# Altium schematic sheet 5.04.51805A02_ STME2.SchDoc("5.04.51805A02_ STME2")
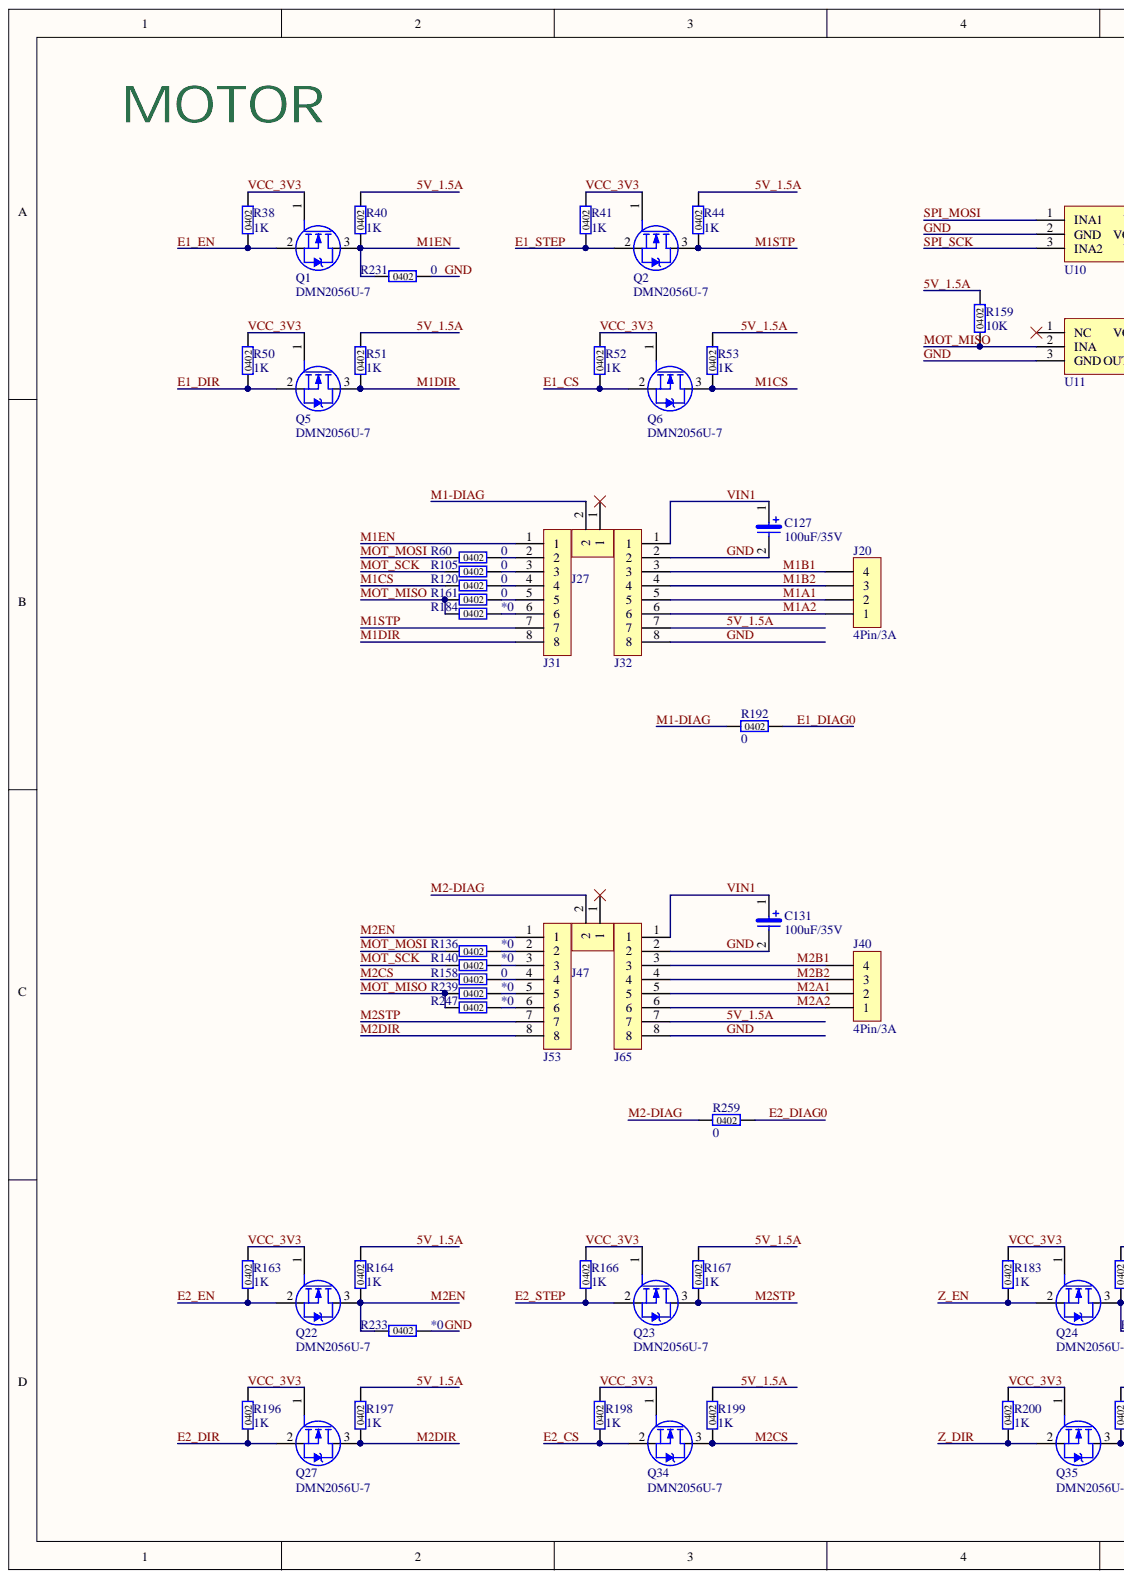
[diagram p0: 5.04.51805A02_ STME2.SchDoc, left]
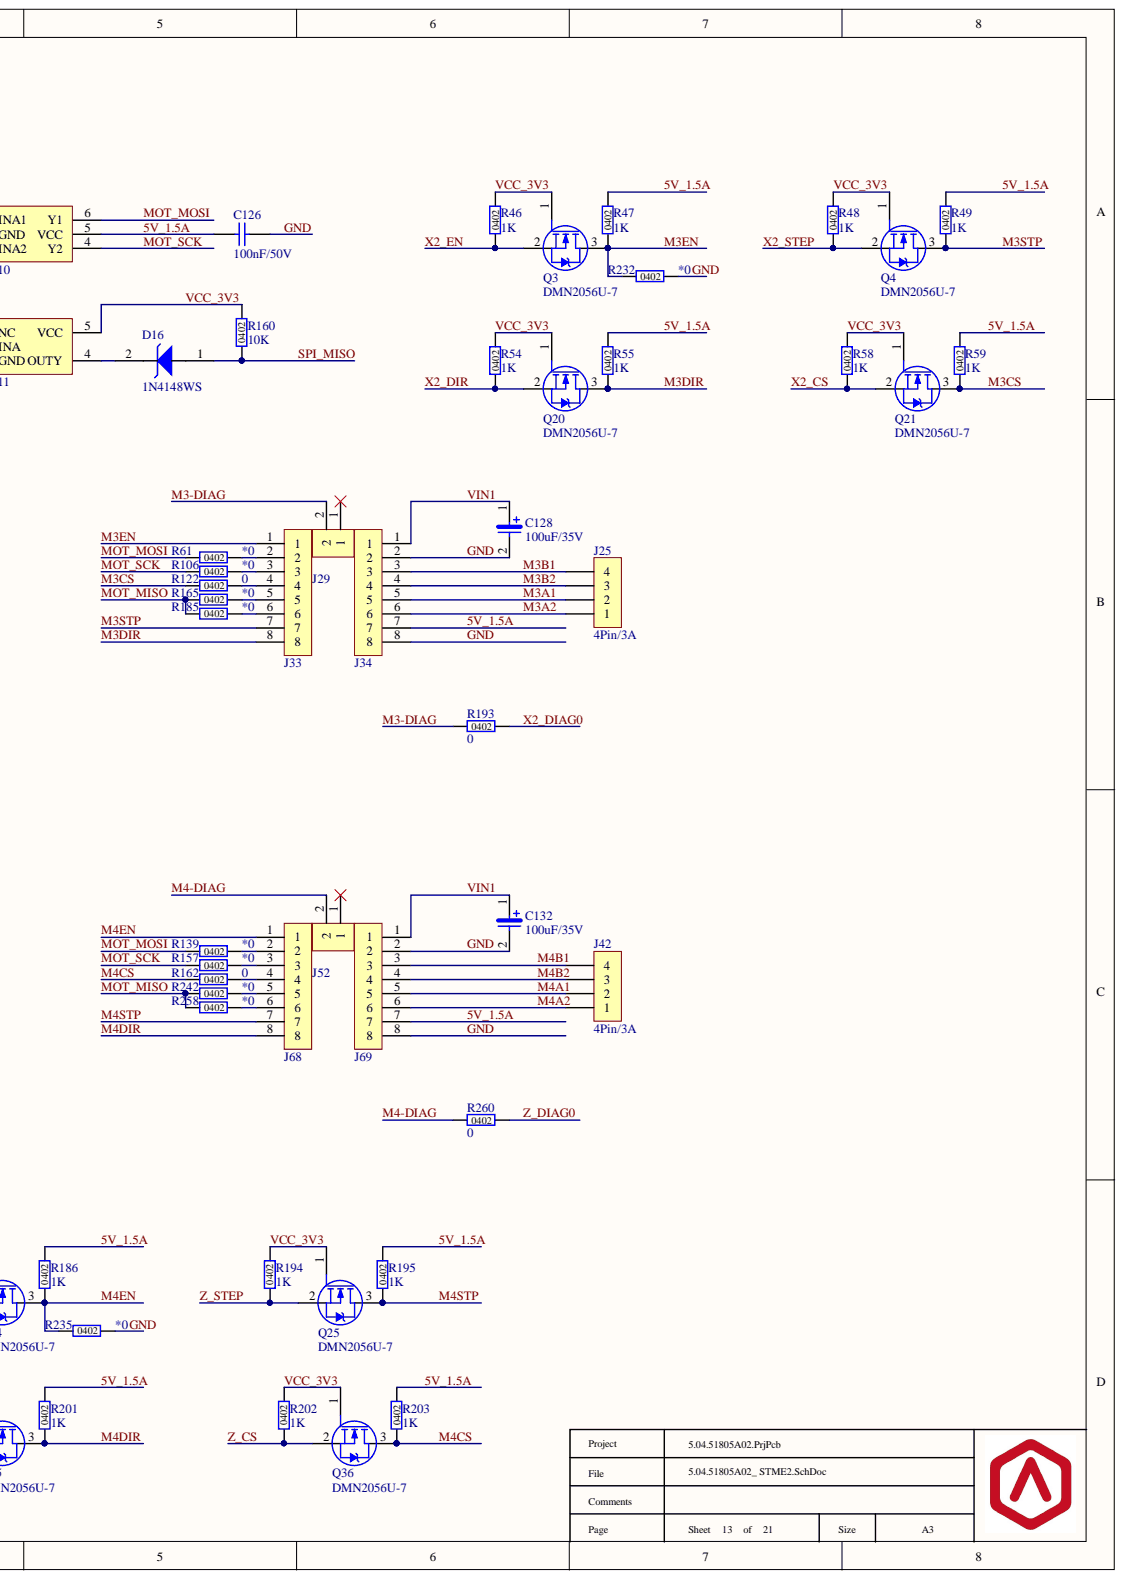
[diagram p1: 5.04.51805A02_ STME2.SchDoc, right]

## Parts
C126  100nF/50V  [C0402]
C127  100uF/35V  [ALC_260_260_307]
C128  100uF/35V  [ALC_260_260_307]
C131  100uF/35V  [ALC_260_260_307]
C132  100uF/35V  [ALC_260_260_307]
D16  1N4148WS  [SOD-323_L1.7-W1.3-LS2.5-FD]
J20  4Pin/3A  [B4B-XH-A(LF)(SN)]
J25  4Pin/3A  [B4B-XH-A(LF)(SN)]
J27  PM254-1-02-Z-8.5  [HDR-TH_2P-P2.54-V-F]  (value not drawn)
J29  PM254-1-02-Z-8.5  [HDR-TH_2P-P2.54-V-F]  (value not drawn)
J31  PM254-1-08-Z-8.5  [HDR-TH_8P-P2.54-V-F]  (value not drawn)
J32  PM254-1-08-Z-8.5  [HDR-TH_8P-P2.54-V-F]  (value not drawn)
J33  PM254-1-08-Z-8.5  [HDR-TH_8P-P2.54-V-F]  (value not drawn)
J34  PM254-1-08-Z-8.5  [HDR-TH_8P-P2.54-V-F]  (value not drawn)
J40  4Pin/3A  [B4B-XH-A(LF)(SN)]
J42  4Pin/3A  [B4B-XH-A(LF)(SN)]
J47  PM254-1-02-Z-8.5  [HDR-TH_2P-P2.54-V-F]  (value not drawn)
J52  PM254-1-02-Z-8.5  [HDR-TH_2P-P2.54-V-F]  (value not drawn)
J53  PM254-1-08-Z-8.5  [HDR-TH_8P-P2.54-V-F]  (value not drawn)
J65  PM254-1-08-Z-8.5  [HDR-TH_8P-P2.54-V-F]  (value not drawn)
J68  PM254-1-08-Z-8.5  [HDR-TH_8P-P2.54-V-F]  (value not drawn)
J69  PM254-1-08-Z-8.5  [HDR-TH_8P-P2.54-V-F]  (value not drawn)
Q1  DMN2056U-7  [SOT-23-3]
Q2  DMN2056U-7  [SOT-23-3]
Q3  DMN2056U-7  [SOT-23-3]
Q4  DMN2056U-7  [SOT-23-3]
Q5  DMN2056U-7  [SOT-23-3]
Q6  DMN2056U-7  [SOT-23-3]
Q20  DMN2056U-7  [SOT-23-3]
Q21  DMN2056U-7  [SOT-23-3]
Q22  DMN2056U-7  [SOT-23-3]
Q23  DMN2056U-7  [SOT-23-3]
Q24  DMN2056U-7  [SOT-23-3]
Q25  DMN2056U-7  [SOT-23-3]
Q27  DMN2056U-7  [SOT-23-3]
Q34  DMN2056U-7  [SOT-23-3]
Q35  DMN2056U-7  [SOT-23-3]
Q36  DMN2056U-7  [SOT-23-3]
R38  1K  [R0402]
R40  1K  [R0402]
R41  1K  [R0402]
R44  1K  [R0402]
R46  1K  [R0402]
R47  1K  [R0402]
R48  1K  [R0402]
R49  1K  [R0402]
R50  1K  [R0402]
R51  1K  [R0402]
R52  1K  [R0402]
R53  1K  [R0402]
R54  1K  [R0402]
R55  1K  [R0402]
R58  1K  [R0402]
R59  1K  [R0402]
R60  0  [R0402]
R61  *0  [R0402]
R105  0  [R0402]
R106  *0  [R0402]
R120  0  [R0402]
R122  0  [R0402]
R136  *0  [R0402]
R139  *0  [R0402]
R140  *0  [R0402]
R157  *0  [R0402]
R158  0  [R0402]
R159  10K  [R0402]
R160  10K  [R0402]
R161  0  [R0402]
R162  0  [R0402]
R163  1K  [R0402]
R164  1K  [R0402]
R165  *0  [R0402]
R166  1K  [R0402]
R167  1K  [R0402]
R183  1K  [R0402]
R184  *0  [R0402]
R185  *0  [R0402]
R186  1K  [R0402]
R192  0  [R0402]
R193  0  [R0402]
R194  1K  [R0402]
R195  1K  [R0402]
R196  1K  [R0402]
R197  1K  [R0402]
R198  1K  [R0402]
R199  1K  [R0402]
R200  1K  [R0402]
R201  1K  [R0402]
R202  1K  [R0402]
R203  1K  [R0402]
R231  0  [R0402]
R232  *0  [R0402]
R233  *0  [R0402]
R235  *0  [R0402]
R239  *0  [R0402]
R242  *0  [R0402]
R247  *0  [R0402]
R258  *0  [R0402]
R259  0  [R0402]
R260  0  [R0402]
U10  SN74LVC2G34DBVR  [SOT-23-6_L2.9-W1.6-P0.95-LS2.8-BR]  (value not drawn)
U11  SN74LVC1G34DCKR  [SC-70-5_L2.0-W1.3-P0.65-LS2.1-BR]  (value not drawn)

## Nets
5V_1.5A: C126.1 J32.7 J34.7 J65.7 J69.7 R40.2 R44.2 R47.2 R49.2 R51.2 R53.2 R55.2 R59.2 R159.2 R164.2 R167.2 R186.2 R195.2 R197.2 R199.2 R201.2 R203.2 U10.5(VCC)
E1_CS: Q6.2 R52.1
E1_DIAG0: R192.2
E1_DIR: Q5.2 R50.1
E1_EN: Q1.2 R38.1
E1_STEP: Q2.2 R41.1
E2_CS: Q34.2 R198.1
E2_DIAG0: R259.2
E2_DIR: Q27.2 R196.1
E2_EN: Q22.2 R163.1
E2_STEP: Q23.2 R166.1
GND: C126.2 C127.2 C128.2 C131.2 C132.2 J32.2 J32.8 J34.2 J34.8 J65.2 J65.8 J69.2 J69.8 R231.2 R232.2 R233.2 R235.2 U10.2(GND) U11.3(GND)
M1-DIAG: J27.2 R192.1
M1A1: J20.2 J32.5
M1A2: J20.1 J32.6
M1B1: J20.4 J32.3
M1B2: J20.3 J32.4
M1CS: Q6.3 R53.1 R120.1
M1DIR: J31.8 Q5.3 R51.1
M1EN: J31.1 Q1.3 R40.1 R231.1
M1STP: J31.7 Q2.3 R44.1
M2-DIAG: J47.2 R259.1
M2A1: J40.2 J65.5
M2A2: J40.1 J65.6
M2B1: J40.4 J65.3
M2B2: J40.3 J65.4
M2CS: Q34.3 R158.1 R199.1
M2DIR: J53.8 Q27.3 R197.1
M2EN: J53.1 Q22.3 R164.1 R233.1
M2STP: J53.7 Q23.3 R167.1
M3-DIAG: J29.2 R193.1
M3A1: J25.2 J34.5
M3A2: J25.1 J34.6
M3B1: J25.4 J34.3
M3B2: J25.3 J34.4
M3CS: Q21.3 R59.1 R122.1
M3DIR: J33.8 Q20.3 R55.1
M3EN: J33.1 Q3.3 R47.1 R232.1
M3STP: J33.7 Q4.3 R49.1
M4-DIAG: J52.2 R260.1
M4A1: J42.2 J69.5
M4A2: J42.1 J69.6
M4B1: J42.4 J69.3
M4B2: J42.3 J69.4
M4CS: Q36.3 R162.1 R203.1
M4DIR: J68.8 Q35.3 R201.1
M4EN: J68.1 Q24.3 R186.1 R235.1
M4STP: J68.7 Q25.3 R195.1
MOT_MISO: R159.1 R161.1 R165.1 R184.1 R185.1 R239.1 R242.1 R247.1 R258.1 U11.2(INA)
MOT_MOSI: R60.1 R61.1 R136.1 R139.1 U10.6(Y1)
MOT_SCK: R105.1 R106.1 R140.1 R157.1 U10.4(Y2)
SPI_MISO: D16.1 R160.1
SPI_MOSI: U10.1(INA1)
SPI_SCK: U10.3(INA2)
VCC_3V3: Q1.1 Q2.1 Q3.1 Q4.1 Q5.1 Q6.1 Q20.1 Q21.1 Q22.1 Q23.1 Q24.1 Q25.1 Q27.1 Q34.1 Q35.1 Q36.1 R38.2 R41.2 R46.2 R48.2 R50.2 R52.2 R54.2 R58.2 R160.2 R163.2 R166.2 R183.2 R194.2 R196.2 R198.2 R200.2 R202.2 U11.5(VCC)
VIN1: C127.1 C128.1 C131.1 C132.1 J32.1 J34.1 J65.1 J69.1
X2_CS: Q21.2 R58.1
X2_DIAG0: R193.2
X2_DIR: Q20.2 R54.1
X2_EN: Q3.2 R46.1
X2_STEP: Q4.2 R48.1
Z_CS: Q36.2 R202.1
Z_DIAG0: R260.2
Z_DIR: Q35.2 R200.1
Z_EN: Q24.2 R183.1
Z_STEP: Q25.2 R194.1
NetD16_2: D16.2 U11.4(OUTY)
NetJ31_2: J31.2 R60.2
NetJ31_3: J31.3 R105.2
NetJ31_4: J31.4 R120.2
NetJ31_5: J31.5 R161.2
NetJ31_6: J31.6 R184.2
NetJ33_2: J33.2 R61.2
NetJ33_3: J33.3 R106.2
NetJ33_4: J33.4 R122.2
NetJ33_5: J33.5 R165.2
NetJ33_6: J33.6 R185.2
NetJ53_2: J53.2 R136.2
NetJ53_3: J53.3 R140.2
NetJ53_4: J53.4 R158.2
NetJ53_5: J53.5 R239.2
NetJ53_6: J53.6 R247.2
NetJ68_2: J68.2 R139.2
NetJ68_3: J68.3 R157.2
NetJ68_4: J68.4 R162.2
NetJ68_5: J68.5 R242.2
NetJ68_6: J68.6 R258.2

Not connected: J27 1; J29 1; J47 1; J52 1; U11 1(NC)

## Library data of the parts
C126 = C0402_100nF_50V: Ceramic Capacitor, 100nF, ±10%, 50V, -55°C~125°C, C0402; Manufacturer1: Yageo; ManufacturerPartNumber1: CC0402KRX7R9BB104; Code: 5191C104; Component Kind: Standard; Manufacturer2: MuRata; ManufacturerPartNumber2: GRM155R71H104KE14D; Library Name: Raise3D_Capacitor.SCHLIB
C127 C128 C131 C132 = ALC_100uF_35V: Aluminum Electrolytic Capacitors, 100uF, ±20%, 35V, -55°C~105°C, ALC_660_660_780; Manufacturer1: Panasonic; ManufacturerPartNumber1: EEE-FK1V101XP; Code: 51B7C107; Component Kind: Standard; Library Name: Raise3D_Capacitor.SCHLIB
D16 = 1N4148WS: 开关二极管,1N4148W,1.2V,200mW,SOD-323,-55°C~150°C; Manufacturer1: R+O; Code: C7420319; Component Kind: Standard; ManufacturerPartNumber1: 1N4148WS; Library Name: Raise3D_General.IntLib
J20 J25 J40 J42 = JW_B4B-XH-A(LF)(SN): 线对板连接器,4PINS,Pitch2.5mm,TH,OR,3A,白,-25°C~85°C; Manufacturer1: JST; Code: 55010014; Component Kind: Standard; Manufacturer2: 红星辰（HXT）; ManufacturerPartNumber1: B4B-XH-A(LF)(SN); ManufacturerPartNumber2: 25000-04A-T0P; Library Name: Raise3D_Connector.IntLib
J27 J29 J47 J52 = PM254-1-02-Z-8.5: 排母,1000V,3A,-40℃~105℃,2.54mm; Manufacturer1: HCTL; Code: C2897365; Component Kind: Standard; ManufacturerPartNumber1: PM254-1-02-Z-8.5; Library Name: Raise3D_General.IntLib
J31-J34 J53 J65 J68 J69 = PM254-1-08-Z-8.5: 排母8P,1000V,3A,-40℃~105℃,2.54mm; Manufacturer1: HCTL; Code: C2897371; Component Kind: Standard; ManufacturerPartNumber1: PM254-1-08-Z-8.5; Library Name: Raise3D_General.IntLib
Q1-Q6 Q20-Q25 Q27 Q34-Q36 = NMOS_DMN2056U-7: N-MOSFET,DMN2056U-7,1Channel,Vds=20V,Id=4A,Vgs=+/-8V,TO-236-3,-55°C~150°C; Manufacturer1: DIODES; Code: 54010011; Component Kind: Standard; ManufacturerPartNumber1: DMN2056U-7; Library Name: Raise3D_MOS&BJT.IntLib
R38 R40 R41 R44 R46-R55 R58 R59 R163 R164 R166 R167 R183 R186 R194-R203 = R0402_1K: Resistor 1Kohm,5%,1/16W,R0402; Manufacturer1: Yageo; ManufacturerPartNumber1: RC0402JR-071KL; Code: 5011001K; Component Kind: Standard
  R38 R40 R41 R44 R46-R51 R53-R55 R59 R163 R164 R166 R167 R183 R186 R194-R197 R199-R201 R203: Library Name: Raise3D_Resistor.SCHLIB
  R52 R58 R198 R202: Library Name: Raise3D_Resistor.IntLib
R60 R61 R105 R106 R120 R122 R136 R139 R140 R157 R158 R161 R162 R165 R184 R185 R192 R193 R231-R233 R235 R239 R242 R247 R258-R260 = R0402_0: Resistor 0ohm,1%,1/16W,R0402; Manufacturer1: Yageo; ManufacturerPartNumber1: RC0402FR-070RL; Code: 5001000R; Component Kind: Standard; Library Name: Raise3D_Resistor.IntLib
R159 R160 = R0402_10K: Resistor 10Kohm,1%,1/16W,R0402; Manufacturer1: Yageo; ManufacturerPartNumber1: RC0402FR-0710KL; Code: 5001010K; Component Kind: Standard; Manufacturer2: Samsung; ManufacturerPartNumber2: RC1005F103CS; Library Name: Raise3D_Resistor.IntLib
U10 = SN74LVC2G34DBVR: 缓冲器,1.65~5.5V,2ports,-40℃~85℃,SOT23-6; Manufacturer1: UMW; Code: C7394039; Component Kind: Standard; ManufacturerPartNumber1: SN74LVC2G34DBVR; Library Name: Raise3D_General.IntLib
U11 = SN74LVC1G34DCKR: 缓冲器,1.65~5.5V,1ports,-40℃~85℃,SC-70-5; Manufacturer1: UMW; Code: C7394031; Component Kind: Standard; ManufacturerPartNumber1: SN74LVC1G34DCKR; Library Name: Raise3D_General.IntLib
